# Altium schematic sheet motor_driver.SchDoc(motor_driver)
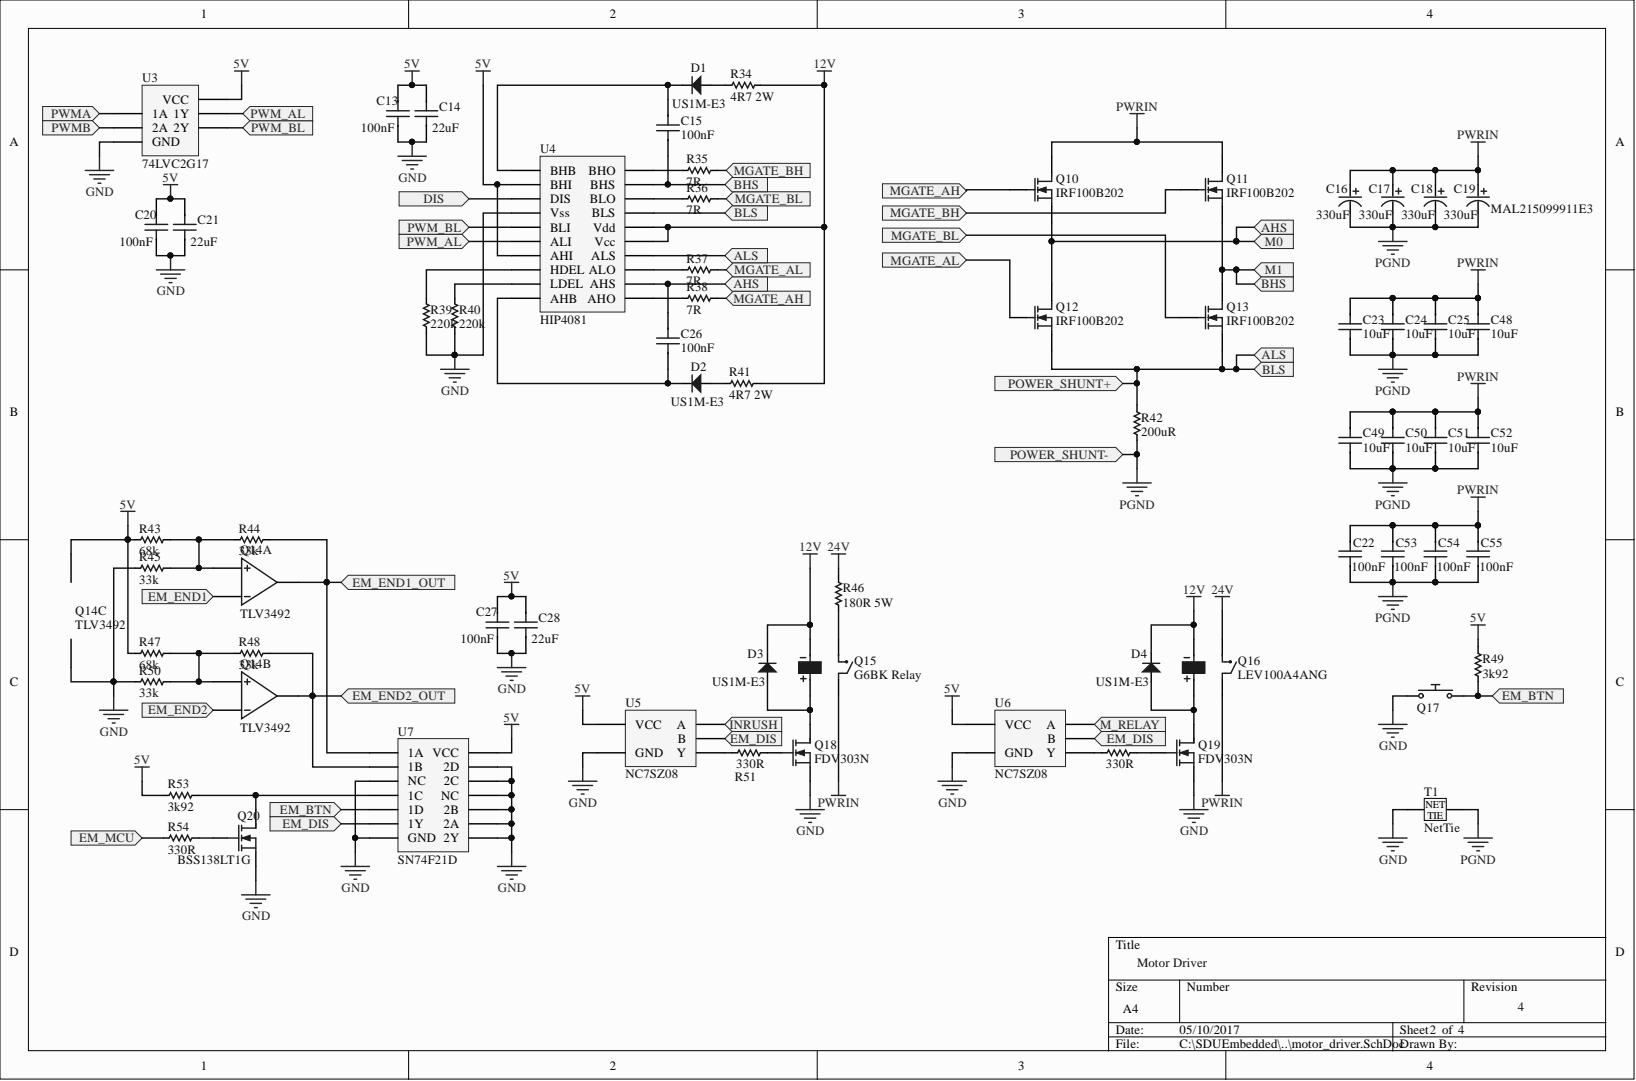
[diagram p0: motor_driver.SchDoc]

## Parts
C13  value 100nF  [CAP-0805]  (hidden comment: Capacitor)
C14  value 22uF  [CAP-0805]  (hidden comment: Capacitor)
C15  value 100nF  [CAP-0805]  (hidden comment: Capacitor)
C16  MAL215099911E3  value 330uF  [CAP-1621]
C17  MAL215099911E3  value 330uF  [CAP-1621]
C18  MAL215099911E3  value 330uF  [CAP-1621]
C19  MAL215099911E3  value 330uF  [CAP-1621]
C20  value 100nF  [CAP-0805]  (hidden comment: Capacitor)
C21  value 22uF  [CAP-0805]  (hidden comment: Capacitor)
C22  value 100nF  [CAP-0805]  (hidden comment: Capacitor)
C23  value 10uF  [CAP-0805]  (hidden comment: Capacitor)
C24  value 10uF  [CAP-0805]  (hidden comment: Capacitor)
C25  value 10uF  [CAP-0805]  (hidden comment: Capacitor)
C26  value 100nF  [CAP-0805]  (hidden comment: Capacitor)
C27  value 100nF  [CAP-0805]  (hidden comment: Capacitor)
C28  value 22uF  [CAP-0805]  (hidden comment: Capacitor)
C48  value 10uF  [CAP-0805]  (hidden comment: Capacitor)
C49  value 10uF  [CAP-0805]  (hidden comment: Capacitor)
C50  value 10uF  [CAP-0805]  (hidden comment: Capacitor)
C51  value 10uF  [CAP-0805]  (hidden comment: Capacitor)
C52  value 10uF  [CAP-0805]  (hidden comment: Capacitor)
C53  value 100nF  [CAP-0805]  (hidden comment: Capacitor)
C54  value 100nF  [CAP-0805]  (hidden comment: Capacitor)
C55  value 100nF  [CAP-0805]  (hidden comment: Capacitor)
D1  US1M-E3  [DO-214AC]
D2  US1M-E3  [DO-214AC]
D3  US1M-E3  [DO-214AC]
D4  US1M-E3  [DO-214AC]
Q10  IRF100B202  [TO-220AB]
Q11  IRF100B202  [TO-220AB]
Q12  IRF100B202  [TO-220AB]
Q13  IRF100B202  [TO-220AB]
Q14  TLV3492  [SOT23-8]  (parts A,B,C)
Q15  G6BK Relay  [G6BK]
Q16  LEV100A4ANG  [ZH-2P]
Q17  Emergency Button  [2P-TERM]  (value not drawn)
Q18  FDV303N  [SOT-23B]
Q19  FDV303N  [SOT-23B]
Q20  BSS138LT1G  [SOT-23B]
R34  value 4R7 2W  [RES-2512]  (hidden comment: Resistor)
R35  value 7R  [RES-0805]  (hidden comment: Resistor)
R36  value 7R  [RES-0805]  (hidden comment: Resistor)
R37  value 7R  [RES-0805]  (hidden comment: Resistor)
R38  value 7R  [RES-0805]  (hidden comment: Resistor)
R39  value 220k  [RES-0805]  (hidden comment: Resistor)
R40  value 220k  [RES-0805]  (hidden comment: Resistor)
R41  value 4R7 2W  [RES-2512]  (hidden comment: Resistor)
R42  value 200uR  [RES-3920]  (hidden comment: Resistor)
R43  value 68k  [RES-0805]  (hidden comment: Resistor)
R44  value 33k  [RES-0805]  (hidden comment: Resistor)
R45  value 33k  [RES-0805]  (hidden comment: Resistor)
R46  value 180R 5W  [RES-RWS5]  (hidden comment: Resistor)
R47  value 68k  [RES-0805]  (hidden comment: Resistor)
R48  value 33k  [RES-0805]  (hidden comment: Resistor)
R49  value 3k92  [RES-0805]  (hidden comment: Resistor)
R50  value 33k  [RES-0805]  (hidden comment: Resistor)
R51  value 330R  [RES-0805]  (hidden comment: Resistor)
R52  value 330R  [RES-0805]  (hidden comment: Resistor)
R53  value 3k92  [RES-0805]  (hidden comment: Resistor)
R54  value 330R  [RES-0805]  (hidden comment: Resistor)
T1  NetTie  [NetTie]
U3  74LVC2G17  [SOT-363]
U4  HIP4081  [SOIC-20 WB]
U5  NC7SZ08  [SOT23-5]
U6  NC7SZ08  [SOT23-5]
U7  SN74F21D  [SOIC-14]

## Ports
AHS, ALS, BHS, BLS, DIS, EM_BTN, EM_DIS, EM_END1, EM_END1_OUT, EM_END2, EM_END2_OUT, EM_MCU, INRUSH, M0, M1, M_RELAY, MGATE_AH, MGATE_AL, MGATE_BH, MGATE_BL, POWER_SHUNT+, POWER_SHUNT-, PWM_AL, PWM_BL, PWMA, PWMB

## Nets
5V: C13.2 C14.2 C20.2 C21.2 C27.2 C28.2 Q14.8 R43.1 R47.1 R49.2 R53.1 U3.5(VCC) U4.2(BHI) U4.7(AHI) U5.5(VCC) U6.5(VCC) U7.14(VCC)
12V: D3.1 D4.1 Q15.1 Q16.1 R34.1 R41.2 U4.15(Vcc) U4.16(Vdd)
24V: Q16.9 R46.2
GND: C13.1 C14.1 C20.1 C21.1 C27.1 C28.1 Q14.4 Q17.1 Q18.2 Q19.2 Q20.2 R39.2 R40.2 R45.1 R50.1 T1.2 U3.2(GND) U4.4(Vss) U5.3(GND) U6.3(GND) U7.3(NC) U7.7(GND) U7.8(2Y) U7.9(2A) U7.10(2B) U7.11(NC) U7.12(2C) U7.13(2D)
PGND: C16.2 C17.2 C18.2 C19.2 C22.1 C23.1 C24.1 C25.1 C48.1 C49.1 C50.1 C51.1 C52.1 C53.1 C54.1 C55.1 R42.1 T1.1
PWRIN: C16.1 C17.1 C18.1 C19.1 C22.2 C23.2 C24.2 C25.2 C48.2 C49.2 C50.2 C51.2 C52.2 C53.2 C54.2 C55.2 Q10.2 Q11.2 Q15.3 Q16.10
AHS: C26.2 Q10.3 Q12.2 U4.12(AHS) ; port AHS, port M0
ALS: Q12.3 Q13.3 R42.2 U4.14(ALS) U4.17(BLS) ; port ALS, port BLS
BHS: C15.1 Q11.3 Q13.2 U4.19(BHS) ; port BHS, port M1
DIS: U4.3(DIS) ; port DIS
EM_BTN: Q17.2 R49.1 U7.5(1D) ; port EM_BTN
EM_DIS: U5.2(B) U6.2(B) U7.6(1Y) ; port EM_DIS
EM_END1: Q14.2 ; port EM_END1
EM_END1_OUT: Q14.1 R44.2 U7.1(1A) ; port EM_END1_OUT
EM_END2: Q14.6 ; port EM_END2
EM_END2_OUT: Q14.7 R48.2 U7.2(1B) ; port EM_END2_OUT
EM_MCU: R54.1 ; port EM_MCU
INRUSH: U5.1(A) ; port INRUSH
M_RELAY: U6.1(A) ; port M_RELAY
MGATE_AH: Q10.1 R38.2 ; port MGATE_AH
MGATE_AL: Q12.1 R37.2 ; port MGATE_AL
MGATE_BH: Q11.1 R35.2 ; port MGATE_BH
MGATE_BL: Q13.1 R36.2 ; port MGATE_BL
PWM_AL: U3.6(1Y) U4.6(ALI) ; port PWM_AL
PWM_BL: U3.4(2Y) U4.5(BLI) ; port PWM_BL
PWMA: U3.1(1A) ; port PWMA
PWMB: U3.3(2A) ; port PWMB
NetC15_2: C15.2 D1.1 U4.1(BHB)
NetC26_1: C26.1 D2.1 U4.10(AHB)
NetD1_2: D1.2 R34.2
NetD2_2: D2.2 R41.1
NetD3_2: D3.2 Q15.6 Q18.3
NetD4_2: D4.2 Q16.2 Q19.3
NetQ14_3: Q14.3 R43.2 R44.1 R45.2
NetQ14_5: Q14.5 R47.2 R48.1 R50.2
NetQ15_4: Q15.4 R46.1
NetQ18_1: Q18.1 R51.2
NetQ19_1: Q19.1 R52.2
NetQ20_1: Q20.1 R54.2
NetQ20_3: Q20.3 R53.2 U7.4(1C)
NetR35_1: R35.1 U4.20(BHO)
NetR36_1: R36.1 U4.18(BLO)
NetR37_1: R37.1 U4.13(ALO)
NetR38_1: R38.1 U4.11(AHO)
NetR39_1: R39.1 U4.8(HDEL)
NetR40_1: R40.1 U4.9(LDEL)
NetR51_1: R51.1 U5.4(Y)
NetR52_1: R52.1 U6.4(Y)

## Library data of the parts
C16-C19 = Electrolytic Capacitor: Component Kind: Standard; Library Name: SDUEmbedded.SchLib
D1-D4 = Diode: Component Kind: Standard; Library Name: SDUEmbedded.SchLib
Q20 = BSS138LT1G: N-Channel MOSFET; Published: 8-Jun-2000; PackageVersion: 1995/1996; PackageReference: E3; PackageDescription: TO, Flat Index; 3 In-Line, Axial Leads; Body Dia. 4.6mm; Lead Dia. 0.5 mm (max); LatestRevisionDate: 17-Jul-2002; Component Kind: Standard; Publisher: Altium Limited; Library Name: SDUEmbedded.SchLib
U3 = 74LVC2G17: 74LVC2G17 Dual non-inverting Schmitt-trigger with 5v tolerant input; Component Kind: Standard; Library Name: SDUEmbedded.SchLib
U7 = SN74F21D: Dual 4-Input AND Gate; Component Kind: Standard; Library Name: SDUEmbedded.SchLib
C13-C15 C20-C28 C48-C55 = Capacitor: Description: Capacitor; Component Kind: Standard; Library Name: SDUEmbedded.SchLib
Q10-Q13 = IRF100B202: Component Kind: Standard; Library Name: SDUEmbedded.SchLib
Q14 = TLV3492: Component Kind: Standard; Library Name: SDUEmbedded.SchLib
Q15 = G6BK Relay: Component Kind: Standard; Library Name: SDUEmbedded.SchLib
Q16 = LEV100A4ANG: Component Kind: Standard; Library Name: SDUEmbedded.SchLib
Q17 = Emergency Button: Component Kind: Standard; Library Name: SDUEmbedded.SchLib
Q18 Q19 = FDV303N: Component Kind: Standard; Library Name: SDUEmbedded.SchLib
R34-R54 = Resistor: Description: Resistor; Component Kind: Standard; Library Name: SDUEmbedded.SchLib
T1 = NetTie: Component Kind: Net Tie; Library Name: SDUEmbedded.SchLib
U4 = HIP4081: Component Kind: Standard; Library Name: SDUEmbedded.SchLib
U5 U6 = NC7SZ08: Component Kind: Standard; Library Name: SDUEmbedded.SchLib
